# Altium schematic sheet NB-iot核心板.SchDoc
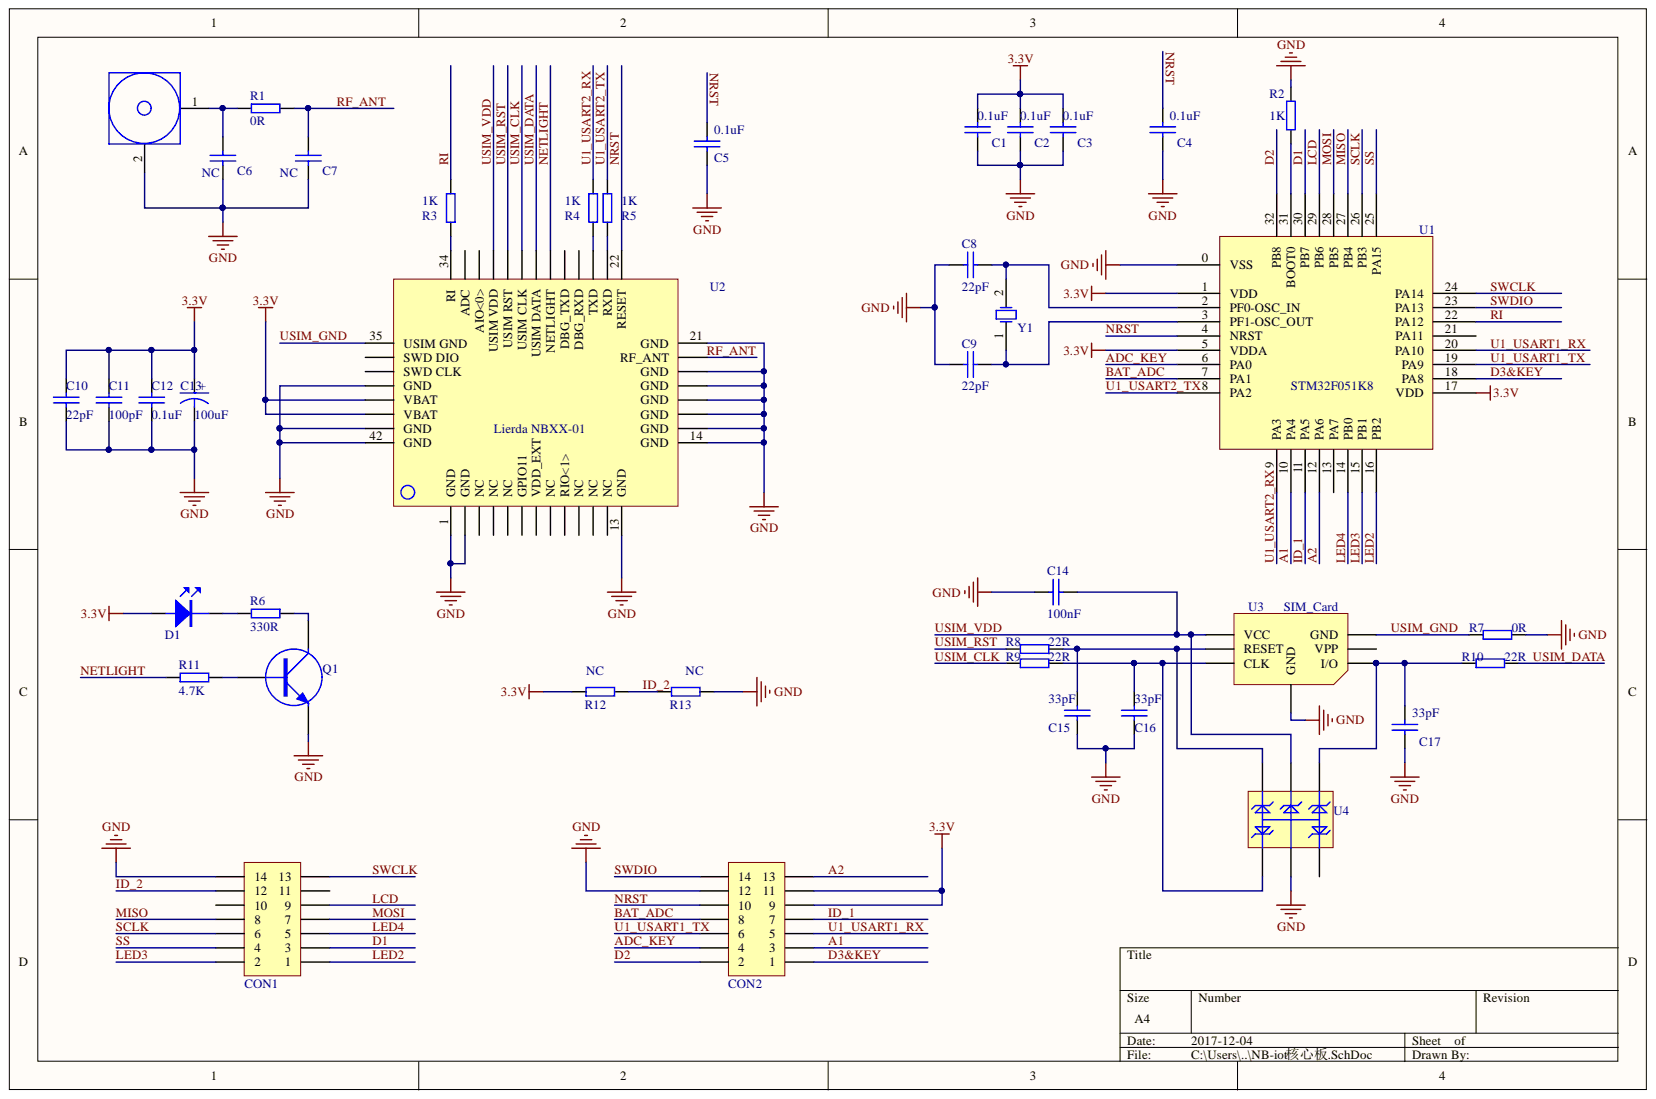
[diagram p0: NB-iot核心板.SchDoc]

## Parts
ANTENNA  ANTENNA  [SMA-KE]  (value not drawn)
C1  0.1uF  [0402-Cap]
C2  0.1uF  [0402-Cap]
C3  0.1uF  [0402-Cap]
C4  0.1uF  [0402-Cap]
C5  0.1uF  [0402-Cap]
C6  NC  [0402-Cap]
C7  NC  [0402-Cap]
C8  22pF  [0402-Cap]
C9  22pF  [0402-Cap]
C10  22pF  [0402-Cap]
C11  100pF  [0402-Cap]
C12  0.1uF  [0402-Cap]
C13  100uF  [Cap_A_3216]
C14  100nF  [0402-Cap]
C15  33pF  [0402-Cap]
C16  33pF  [0402-Cap]
C17  33pF  [0402-Cap]
CON1  HEADER 7X2  [HDR2X7_Down]  (value not drawn)
CON2  HEADER 7X2  [HDR2X7_Down]  (value not drawn)
D1  LED  [LED0402_R]  (value not drawn)
Q1  NPN  [SOT-23]  (value not drawn)
R1  0R  [0402-Res]
R2  1K  [0402-Res]
R3  1K  [0402-Res]
R4  1K  [0402-Res]
R5  1K  [0402-Res]
R6  330R  [0402-Res]
R7  0R  [0402-Res]
R8  22R  [0402-Res]
R9  22R  [0402-Res]
R10  22R  [0402-Res]
R11  4.7K  [0402-Res]
R12  NC  [0402-Res]
R13  NC  [0402-Res]
U1  STM32F051K8  [QFN_64]
U2  Lierda NBXX-01  [Lierda MBXX-01]
U3  SIM_Card  [Micro_SIM]
U4  SMF05CT1G  [SMF05CT1G]  (value not drawn)
Y1  MHZ  [3225]  (value not drawn)

## Nets
3.3V: C1.2 C2.2 C3.2 C10.2 C11.2 C12.2 C13.1 CON2.9 CON2.11 D1.A R12.1 U1.1(VDD) U1.5(VDDA) U1.17(VDD) U2.39(VBAT) U2.40(VBAT)
GND: ANTENNA.2 C1.1 C2.1 C3.1 C4.1 C5.2 C6.1 C7.1 C8.1 C9.1 C10.1 C11.1 C12.1 C13.2 C14.2 C15.2 C16.2 C17.2 CON1.14 CON2.12 Q1.2 R2.1 R7.2 R13.2 U1.0(VSS) U2.1(GND) U2.2(GND) U2.13(GND) U2.14(GND) U2.15(GND) U2.16(GND) U2.17(GND) U2.18(GND) U2.19(GND) U2.21(GND) U2.38(GND) U2.41(GND) U2.42(GND) U3.0(GND) U4.2
A1: CON2.3 U1.10(PA4)
A2: CON2.13 U1.12(PA6)
ADC_KEY: CON2.4 U1.6(PA0)
BAT_ADC: CON2.8 U1.7(PA1)
D1: CON1.3 U1.30(PB7)
D2: CON2.2 U1.32(PB8)
D3&KEY: CON2.1 U1.18(PA8)
ID_1: CON2.7 U1.11(PA5)
ID_2: CON1.12 R12.2 R13.1
LCD: CON1.9 U1.29(PB6)
LED2: CON1.1 U1.16(PB2)
LED3: CON1.2 U1.15(PB1)
LED4: CON1.5 U1.14(PB0)
MISO: CON1.8 U1.27(PB4)
MOSI: CON1.7 U1.28(PB5)
NETLIGHT: R11.1 U2.27(NETLIGHT)
NRST: C4.2 C5.1 CON2.10 U1.4(NRST) U2.22(RESET)
RF_ANT: C7.2 R1.2 U2.20(RF_ANT)
RI: R3.2 U1.22(PA12)
SCLK: CON1.6 U1.26(PB3)
SS: CON1.4 U1.25(PA15)
SWCLK: CON1.13 U1.24(PA14)
SWDIO: CON2.14 U1.23(PA13)
U1_USART1_RX: CON2.5 U1.20(PA10)
U1_USART1_TX: CON2.6 U1.19(PA9)
U1_USART2_RX: R4.2 U1.9(PA3)
U1_USART2_TX: R5.1 U1.8(PA2)
USIM_CLK: R9.1 U2.29(USIM_CLK)
USIM_DATA: R10.2 U2.28(USIM_DATA)
USIM_GND: R7.1 U2.35(USIM_GND) U3.C5(GND)
USIM_RST: R8.1 U2.30(USIM_RST)
USIM_VDD: C14.1 U2.31(USIM_VDD) U3.C1(VCC) U4.5
NetANTENNA_1: ANTENNA.1 C6.2 R1.1
NetC8_2: C8.2 U1.2(PF0-OSC_IN) Y1.2
NetC9_2: C9.2 U1.3(PF1-OSC_OUT) Y1.1
NetC15_1: C15.1 R8.2 U3.C2(RESET) U4.6
NetC16_1: C16.1 R9.2 U3.C3(CLK) U4.1
NetC17_1: C17.1 R10.1 U3.C7(I/O) U4.4
NetD1_K: D1.K R6.2
NetQ1_1: Q1.1 R11.2
NetQ1_3: Q1.3 R6.1
NetR2_2: R2.2 U1.31(BOOT0)
NetR3_1: R3.1 U2.34(RI)
NetR4_1: R4.1 U2.24(TXD)
NetR5_2: R5.2 U2.23(RXD)

Not connected: CON1 10 11; U1 13(PA7) 21(PA11); U2 3(NC) 4(NC) 5(NC) 6(GPIO11) 7(VDD_EXT) 8(NC) 9(RIO<1>) 10(NC) 11(NC) 12(NC) 25(DBG_RXD) 26(DBG_TXD) 32(AIO<0>) 33(ADC) 36(SWD_DIO) 37(SWD_CLK); U3 C6(VPP); U4 3

## Library data of the parts
C1-C12 C14-C17 = Cap: ceramics; Component Kind: Standard; Library Name: Library.IntLib
C13 = Cap_A: Electrolytic; Component Kind: Standard; Library Name: Library.IntLib
CON1 CON2 = HEADER 7X2: 排母; Component Kind: Standard; Library Name: Library.IntLib
D1 = LED: 发光二极管; Component Kind: Standard; Library Name: Library.IntLib
R1-R13 = RES: 电阻; Component Kind: Standard; Library Name: Library.IntLib
U1 = STM32F051K6/8: Component Kind: Standard; Library Name: Library.IntLib
U2 = Lierda NBXX-01: NB-iot模组; Component Kind: Standard; Library Name: Library.IntLib
Y1 = XTAL: Crystal Oscillator; Component Kind: Standard; Library Name: Library.IntLib
ANTENNA = ANTENNA: Component Kind: Standard; Library Name: Library.IntLib
Q1 = NPN: Component Kind: Standard; Description: NPN; Library Name: Library.IntLib
U3 = SIM_Card: Component Kind: Standard; Library Name: Library.IntLib
U4 = SMF05CT1G: Component Kind: Standard; Library Name: Library.IntLib
